# Altium schematic sheet FPGA_Power.SchDoc
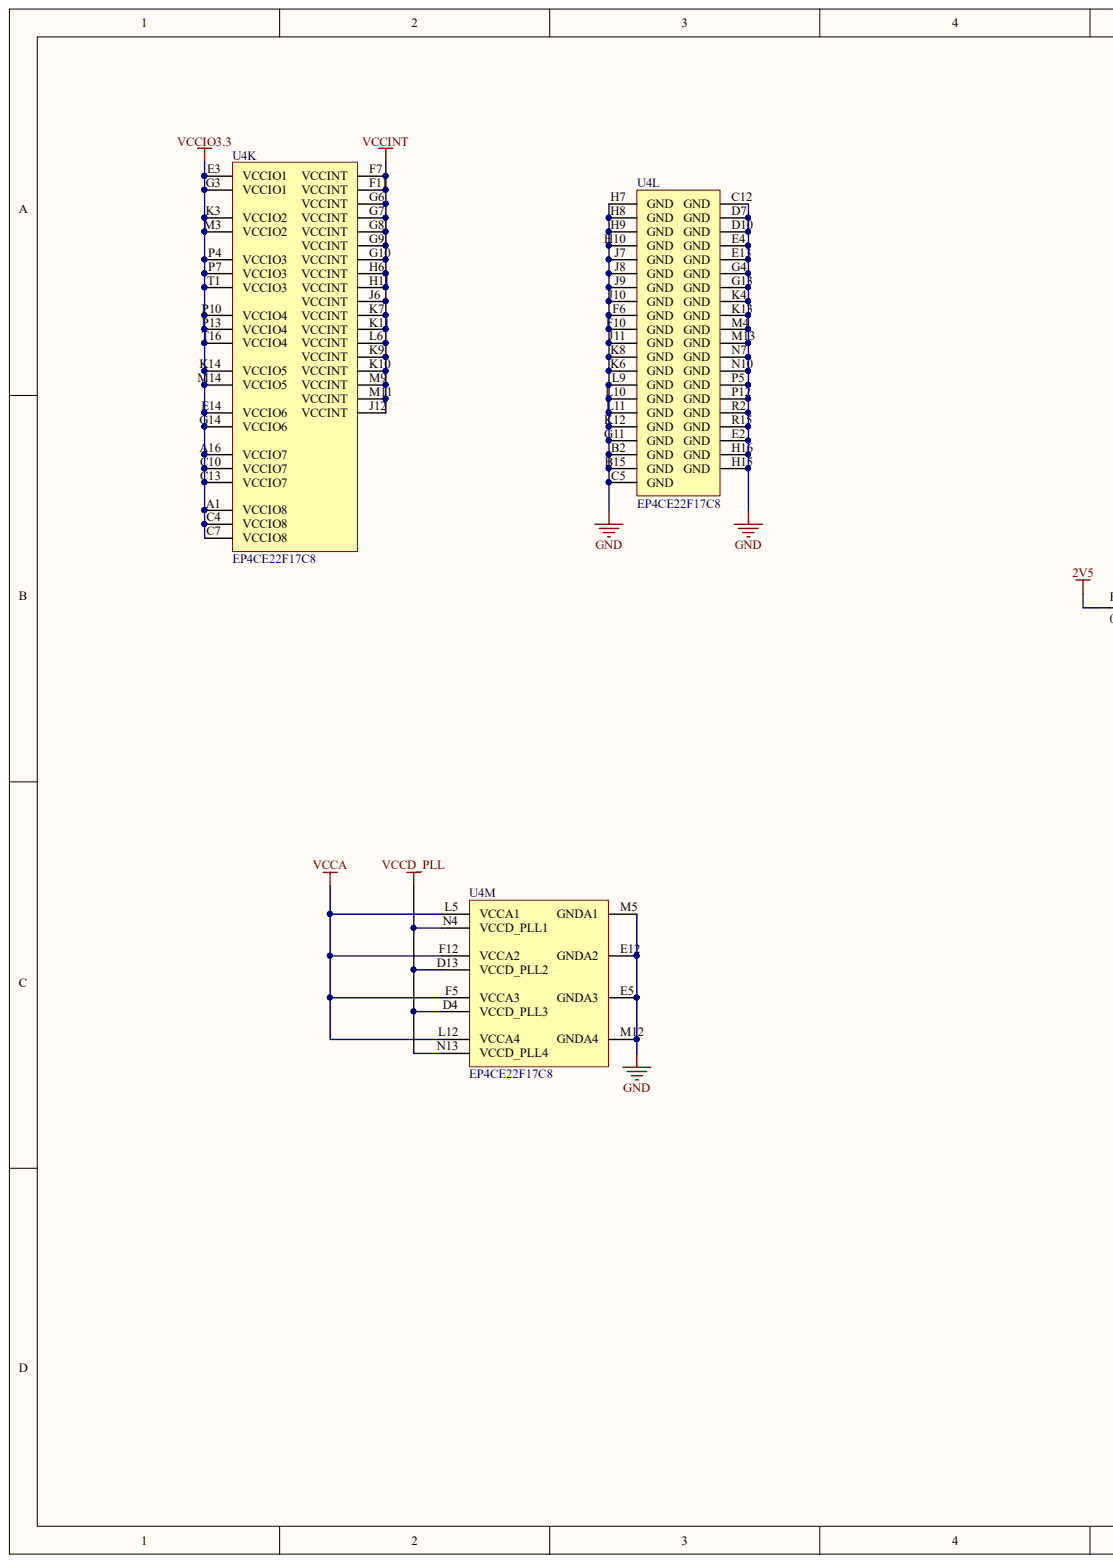
[diagram p0: FPGA_Power.SchDoc, left]
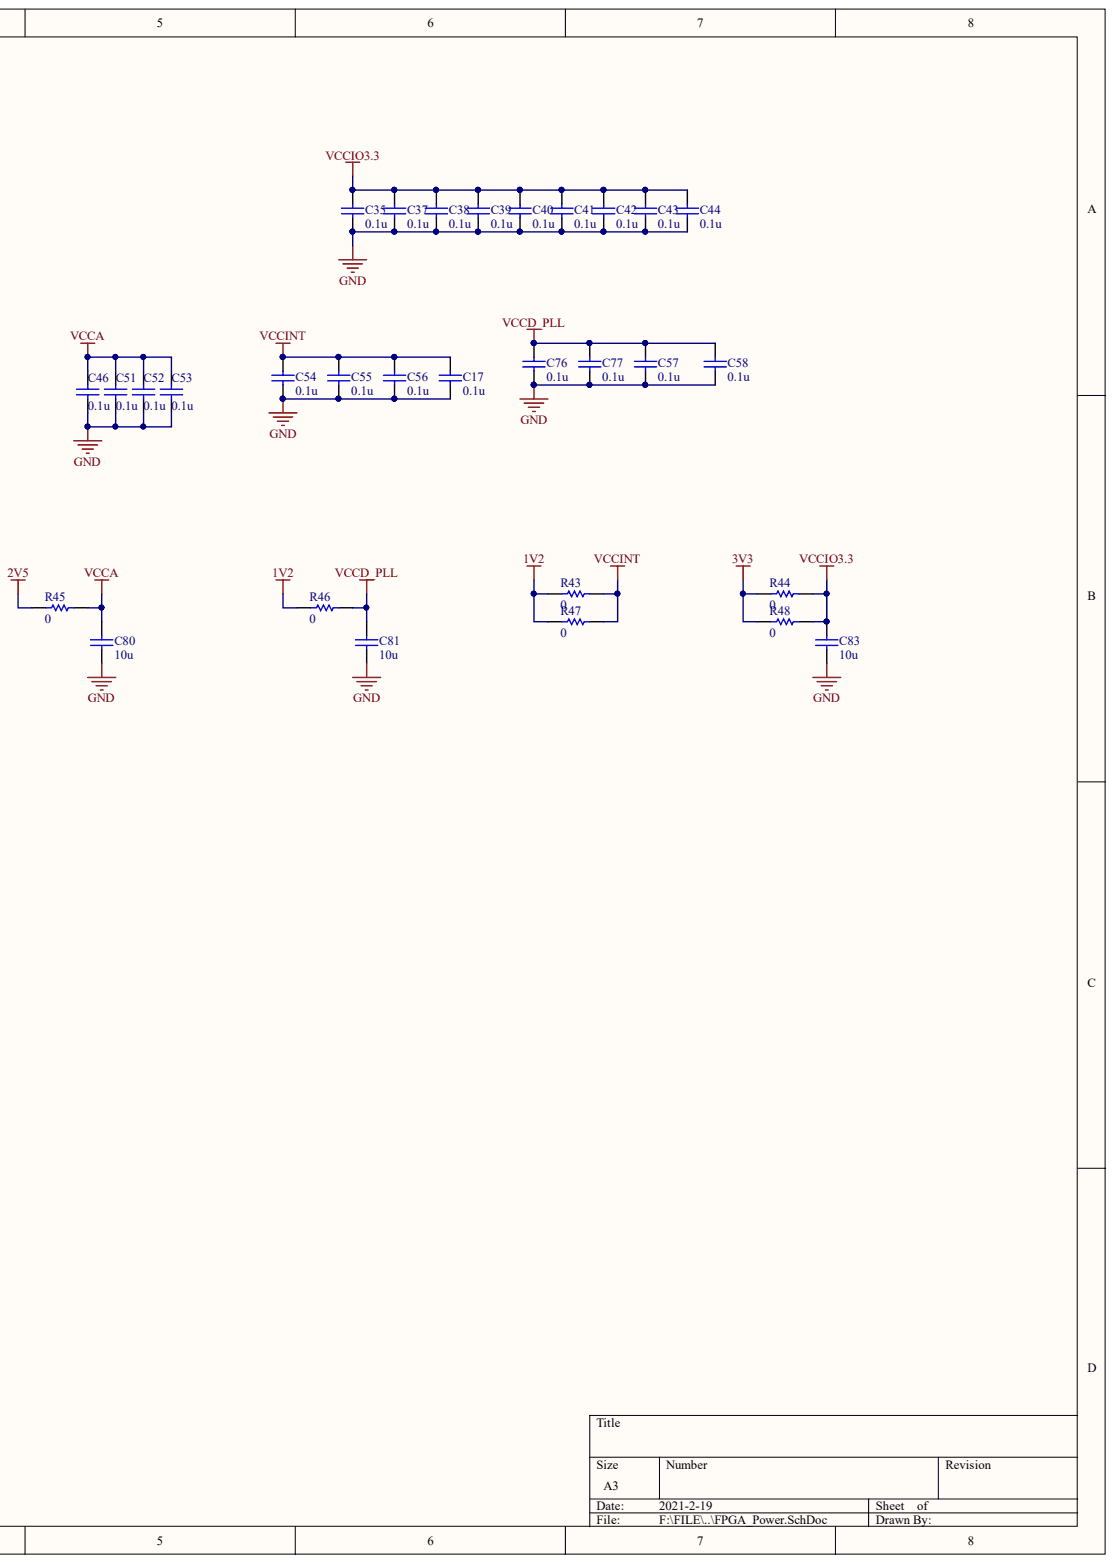
[diagram p1: FPGA_Power.SchDoc, right]

## Parts
C17  0.1u  [C_0402]
C35  0.1u  [0603C]
C37  0.1u  [C0402]
C38  0.1u  [C_0402]
C39  0.1u  [C_0402]
C40  0.1u  [C_0402]
C41  0.1u  [C_0402]
C42  0.1u  [C_0402]
C43  0.1u  [C_0402]
C44  0.1u  [C_0402]
C46  0.1u  [C_0402]
C51  0.1u  [C_0402]
C52  0.1u  [C_0402]
C53  0.1u  [C_0402]
C54  0.1u  [C_0402]
C55  0.1u  [C_0402]
C56  0.1u  [C_0402]
C57  0.1u  [C_0402]
C58  0.1u  [C_0402]
C76  0.1u  [C_0402]
C77  0.1u  [C_0402]
C80  10u  [1206_]
C81  10u  [1206_]
C83  10u  [1206_]
R43  0  [0603_Q0603A]
R44  0  [0603_Q0603A]
R45  0  [0603_Q0603A]
R46  0  [0603_Q0603A]
R47  0  [0603_Q0603A]
R48  0  [0603_Q0603A]
U4  EP4CE22F17C8  [FBGA256]  (parts K,L,M)

## Nets
1V2: R43.1 R46.1 R47.1
2V5: R45.1
3V3: R44.1 R48.1
GND: C17.1 C35.1 C37.1 C38.1 C39.1 C40.1 C41.1 C42.1 C43.1 C44.1 C46.1 C51.1 C52.1 C53.1 C54.1 C55.1 C56.1 C57.1 C58.1 C76.1 C77.1 C80.1 C81.1 C83.1 U4.B2(GND) U4.B15(GND) U4.C5(GND) U4.C12(GND) U4.D7(GND) U4.D10(GND) U4.E2(GND) U4.E4(GND) U4.E5(GNDA3) U4.E12(GNDA2) U4.E13(GND) U4.F6(GND) U4.F10(GND) U4.G4(GND) U4.G11(GND) U4.G13(GND) U4.H7(GND) U4.H8(GND) U4.H9(GND) U4.H10(GND) U4.H15(GND) U4.H16(GND) U4.J7(GND) U4.J8(GND) U4.J9(GND) U4.J10(GND) U4.J11(GND) U4.K4(GND) U4.K6(GND) U4.K8(GND) U4.K12(GND) U4.K13(GND) U4.L9(GND) U4.L10(GND) U4.L11(GND) U4.M4(GND) U4.M5(GNDA1) U4.M12(GNDA4) U4.M13(GND) U4.N7(GND) U4.N10(GND) U4.P5(GND) U4.P12(GND) U4.R2(GND) U4.R15(GND)
VCCA: C46.2 C51.2 C52.2 C53.2 C80.2 R45.2 U4.F5(VCCA3) U4.F12(VCCA2) U4.L5(VCCA1) U4.L12(VCCA4)
VCCD_PLL: C57.2 C58.2 C76.2 C77.2 C81.2 R46.2 U4.D4(VCCD_PLL3) U4.D13(VCCD_PLL2) U4.N4(VCCD_PLL1) U4.N13(VCCD_PLL4)
VCCINT: C17.2 C54.2 C55.2 C56.2 R43.2 R47.2 U4.F7(VCCINT) U4.F11(VCCINT) U4.G6(VCCINT) U4.G7(VCCINT) U4.G8(VCCINT) U4.G9(VCCINT) U4.G10(VCCINT) U4.H6(VCCINT) U4.H11(VCCINT) U4.J6(VCCINT) U4.J12(VCCINT) U4.K7(VCCINT) U4.K9(VCCINT) U4.K10(VCCINT) U4.K11(VCCINT) U4.L6(VCCINT) U4.M9(VCCINT) U4.M11(VCCINT)
VCCIO3.3: C35.2 C37.2 C38.2 C39.2 C40.2 C41.2 C42.2 C43.2 C44.2 C83.2 R44.2 R48.2 U4.A1(VCCIO8) U4.A16(VCCIO7) U4.C4(VCCIO8) U4.C7(VCCIO8) U4.C10(VCCIO7) U4.C13(VCCIO7) U4.E3(VCCIO1) U4.E14(VCCIO6) U4.G3(VCCIO1) U4.G14(VCCIO6) U4.K3(VCCIO2) U4.K14(VCCIO5) U4.M3(VCCIO2) U4.M14(VCCIO5) U4.P4(VCCIO3) U4.P7(VCCIO3) U4.P10(VCCIO4) U4.P13(VCCIO4) U4.T1(VCCIO3) U4.T16(VCCIO4)

## Library data of the parts
C17 C35 C37-C44 C46 C51-C58 C76 C77 C80 C81 C83 = CAP1: Component Kind: Standard; Library Name: the_god_of_death.IntLib
R43-R48 = RES: Component Kind: Standard; Library Name: the_god_of_death.IntLib
U4 = EP4CE22F17C8: Cyclone IV Family FPGA, 2V Core, 153 I/O Pins, 4 PLLs, 256-Pin FBGA, Speed Grade 8, Commercial Grade; Code_IPC: BGA100P16X16-256; Code_JEDEC: MS-034-AAF-1; Component Kind: Standard; ComponentLink1Description: Manufacturer Link; ComponentLink1URL: http://www.altera.com/; ComponentLink2Description: Handbook; ComponentLink2URL: http://www.altera.com/literature/hb/cyclone-iv/cyclone4-handbook.pdf; ComponentLink3Description: Pinout Specification; ComponentLink3URL: http://www.altera.com/literature/lit-dp.jsp?category=cyc%204&showspreadsheet=y; ComponentLink4Description: Packaging Specification; ComponentLink4URL: http://www.altera.com/literature/ds/dspkg.pdf; DatasheetVersion: ver1.2, Mar-2010; Library Name: Altera Cyclone IV E.SchLib; PackageDescription: 256-Ball FineLine Ball Grid Array (FBGA256) - Option 1 - Wire Bond; PackageReference: FBGA256; PackageVersion: ver16.0, Dec-2009; Published: 3-May-2010; Signal Integrity: EP4CE_F256; Publisher: Altium Limited
